# Altium schematic sheet 03_RM3100_Compass.SchDoc(03_RM3100_Compass)
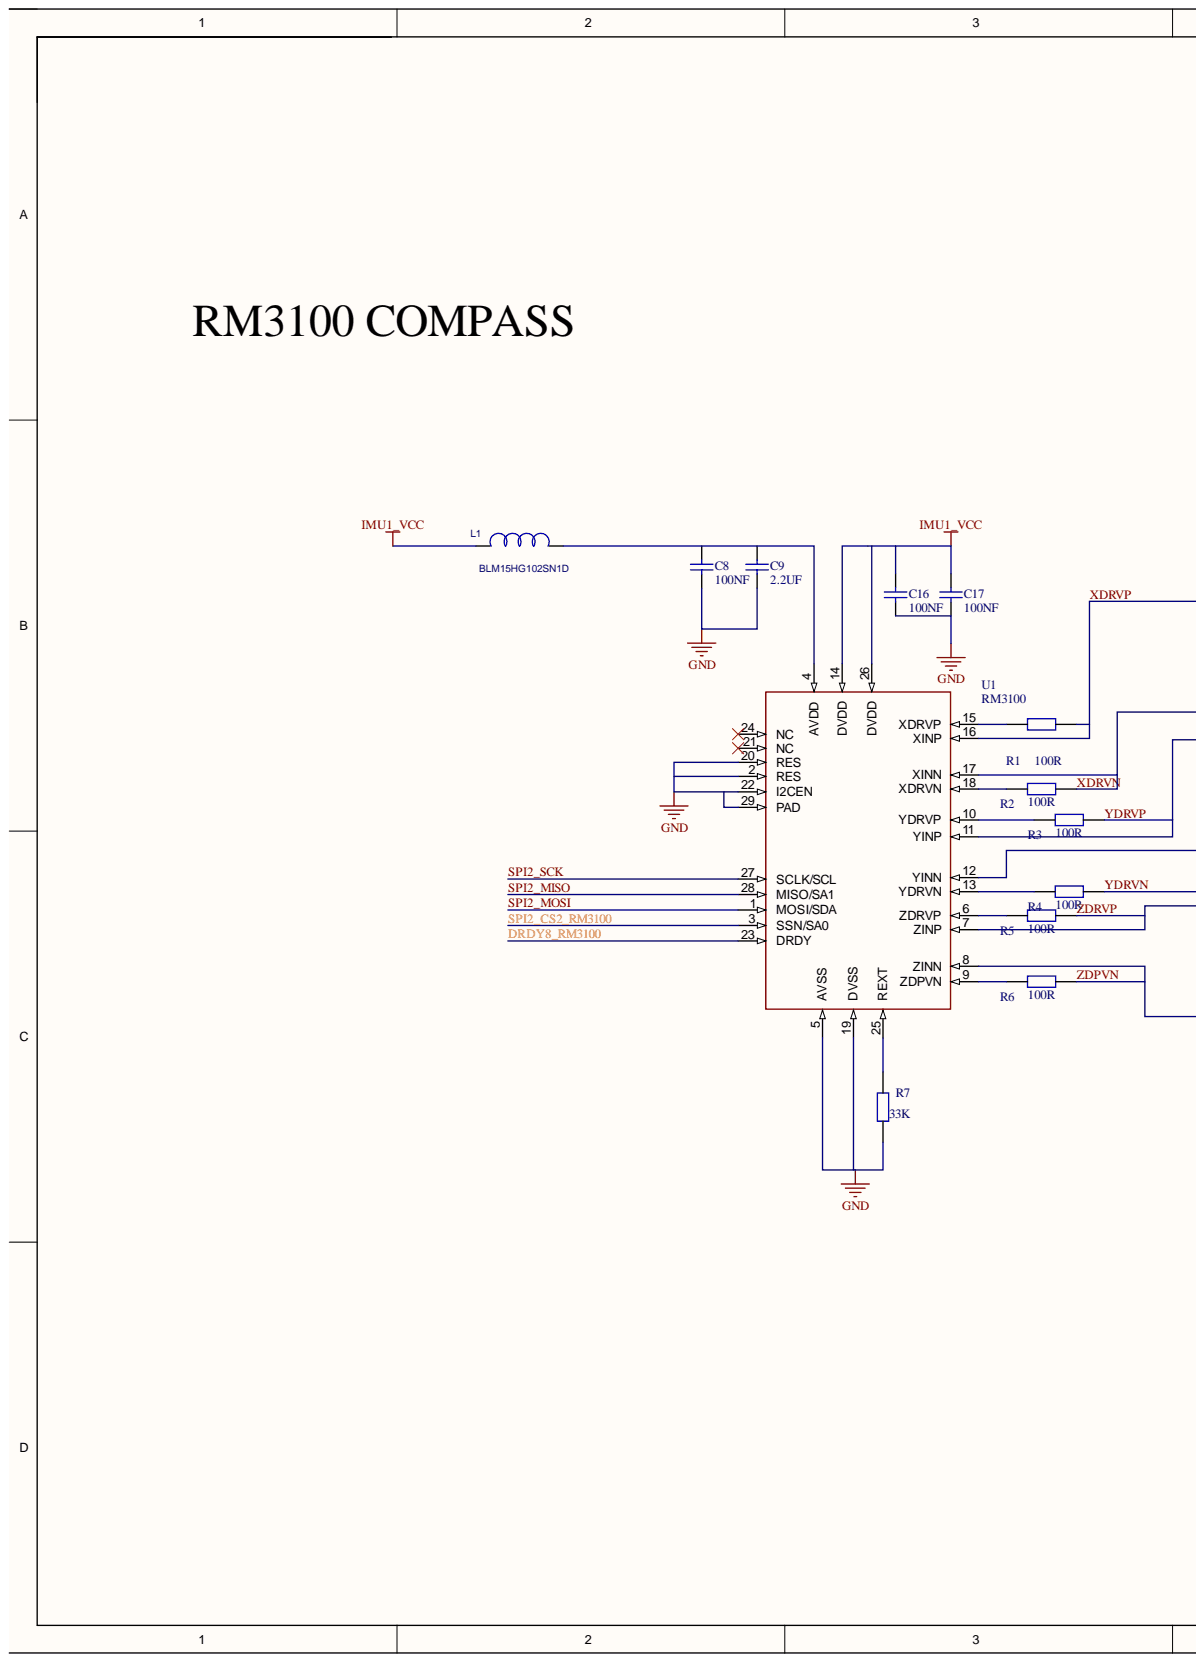
[diagram p0: 03_RM3100_Compass.SchDoc, left]
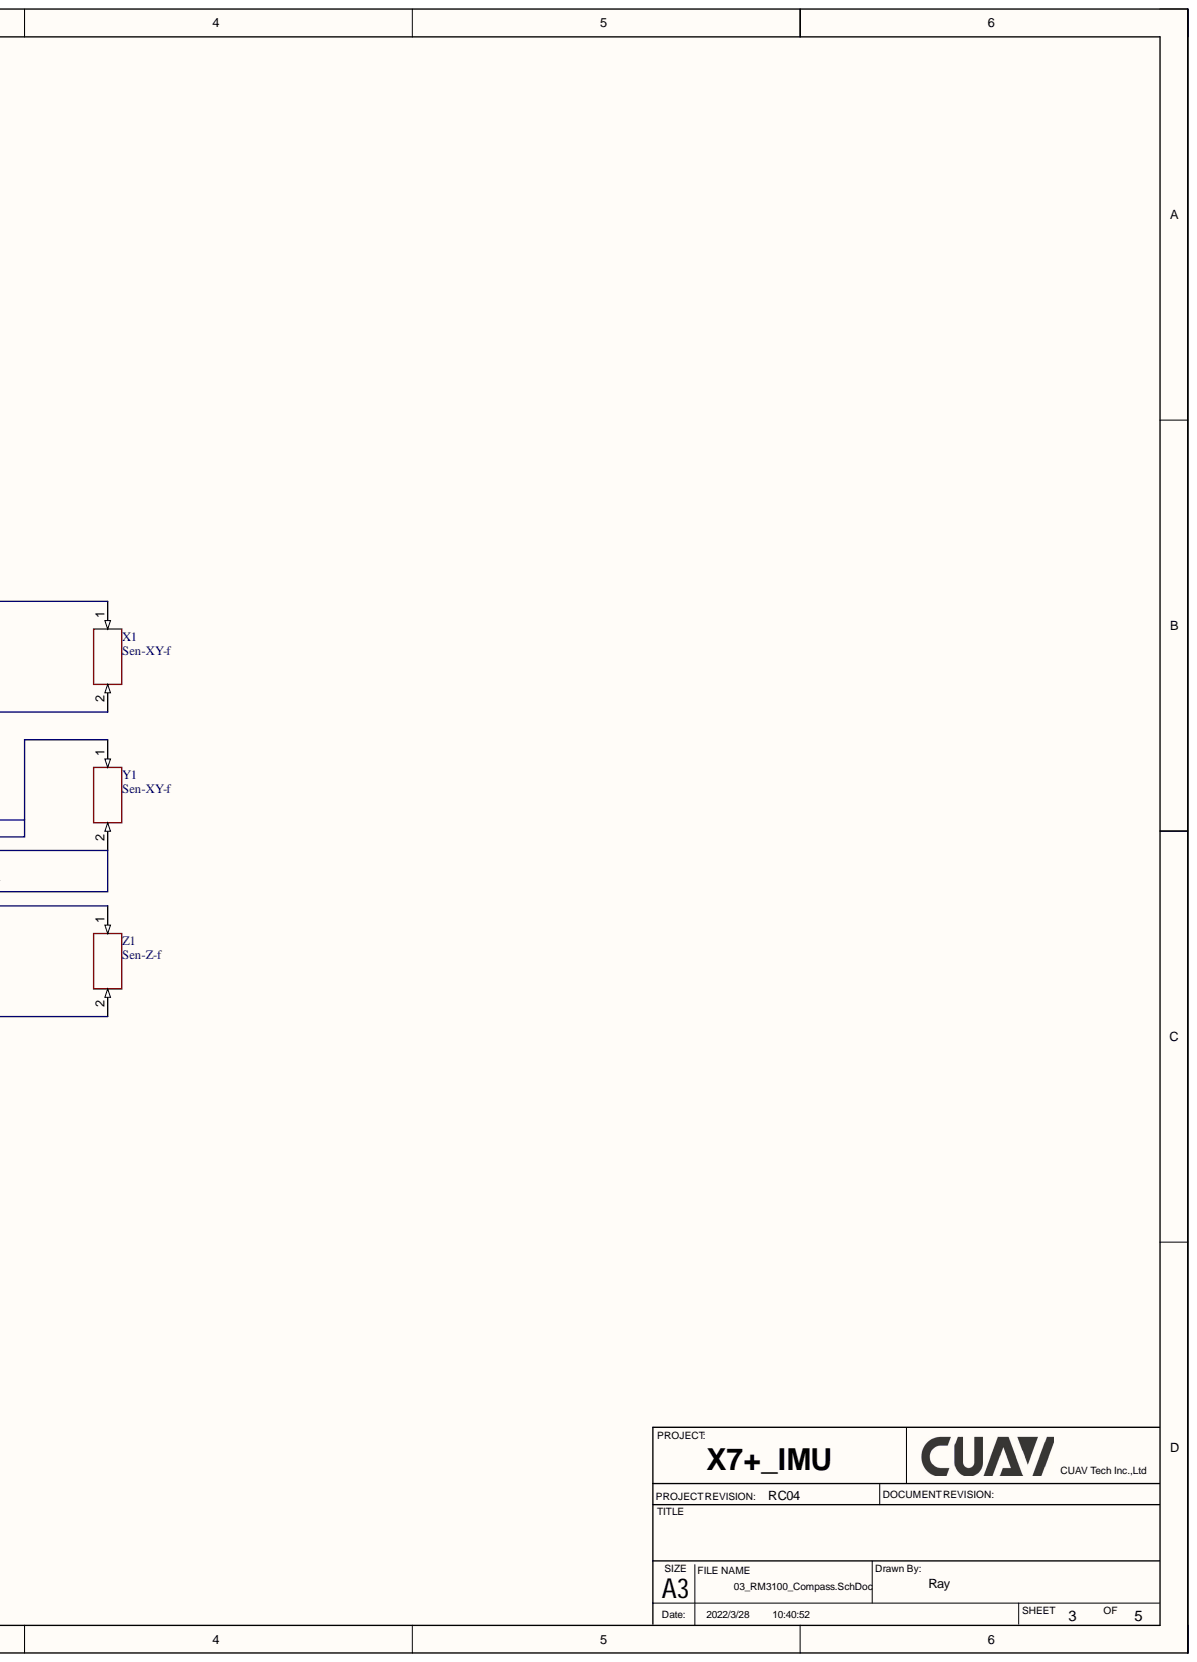
[diagram p1: 03_RM3100_Compass.SchDoc, right]

## Parts
C8  100NF  [0402CAP]
C9  2.2UF  [0402CAP]
C16  100NF  [0402CAP]
C17  100NF  [0402CAP]
L1  BLM15HG102SN1D  [0402R]
R1  100R  [0402R]
R2  100R  [0402R]
R3  100R  [0402R]
R4  100R  [0402R]
R5  100R  [0402R]
R6  100R  [0402R]
R7  33K  [0402R]
U1  RM3100  [QFN24]
X1  Sen-XY-f  [Sen-XY-f]
Y1  Sen-XY-f  [Sen-XY-f]
Z1  Sen-Z-f  [Sen-Z-f]

## Nets
GND: C8.2 C9.2 C16.2 C17.2 R7.2 U1.2(RES) U1.5(AVSS) U1.19(DVSS) U1.20(RES) U1.22(I2CEN) U1.29(PAD)
IMU1_VCC: C16.1 C17.1 L1.1 U1.14(DVDD) U1.26(DVDD)
DRDY8_RM3100: U1.23(DRDY)
SPI2_CS2_RM3100: U1.3(SSN/SA0)
SPI2_MISO: U1.28(MISO/SA1)
SPI2_MOSI: U1.1(MOSI/SDA)
SPI2_SCK: U1.27(SCLK/SCL)
XDRVN: R2.2 U1.17(XINN) X1.2
XDRVP: R1.2 U1.16(XINP) X1.1
YDRVN: R4.2 U1.12(YINN) Y1.2
YDRVP: R3.2 U1.11(YINP) Y1.1
ZDPVN: R6.2 U1.8(ZINN) Z1.2
ZDRVP: R5.2 U1.7(ZINP) Z1.1
NetC8_1: C8.1 C9.1 L1.2 U1.4(AVDD)
NetR1_1: R1.1 U1.15(XDRVP)
NetR2_1: R2.1 U1.18(XDRVN)
NetR3_1: R3.1 U1.10(YDRVP)
NetR4_1: R4.1 U1.13(YDRVN)
NetR5_1: R5.1 U1.6(ZDRVP)
NetR6_1: R6.1 U1.9(ZDPVN)
NetR7_1: R7.1 U1.25(REXT)

Not connected: U1 21(NC) 24(NC)

## Library data of the parts
C8 C16 C17 = Cap: 0402 100NF 50V 10%; Component Kind: Standard; Library Name: Miscellaneous Devices.IntLib; Signal Integrity: Cap; Simulation: CAP
C9 = Cap: Component Kind: Standard; Library Name: Miscellaneous Devices.IntLib; Signal Integrity: Cap; Simulation: CAP
L1 = L_0: Component Kind: Standard
R1-R7 = 0402R0R: Component Kind: Standard; Library Name: CUAV.SchLib
U1 = RM3100: Component Kind: Standard; Library Name: CUAV.SchLib
X1 Y1 = Sen-XY-f: Component Kind: Standard; Library Name: CUAV.SchLib
Z1 = Sen-Z-f: Component Kind: Standard; Library Name: CUAV.SchLib
